# Altium schematic sheet Sheet5.SchDoc
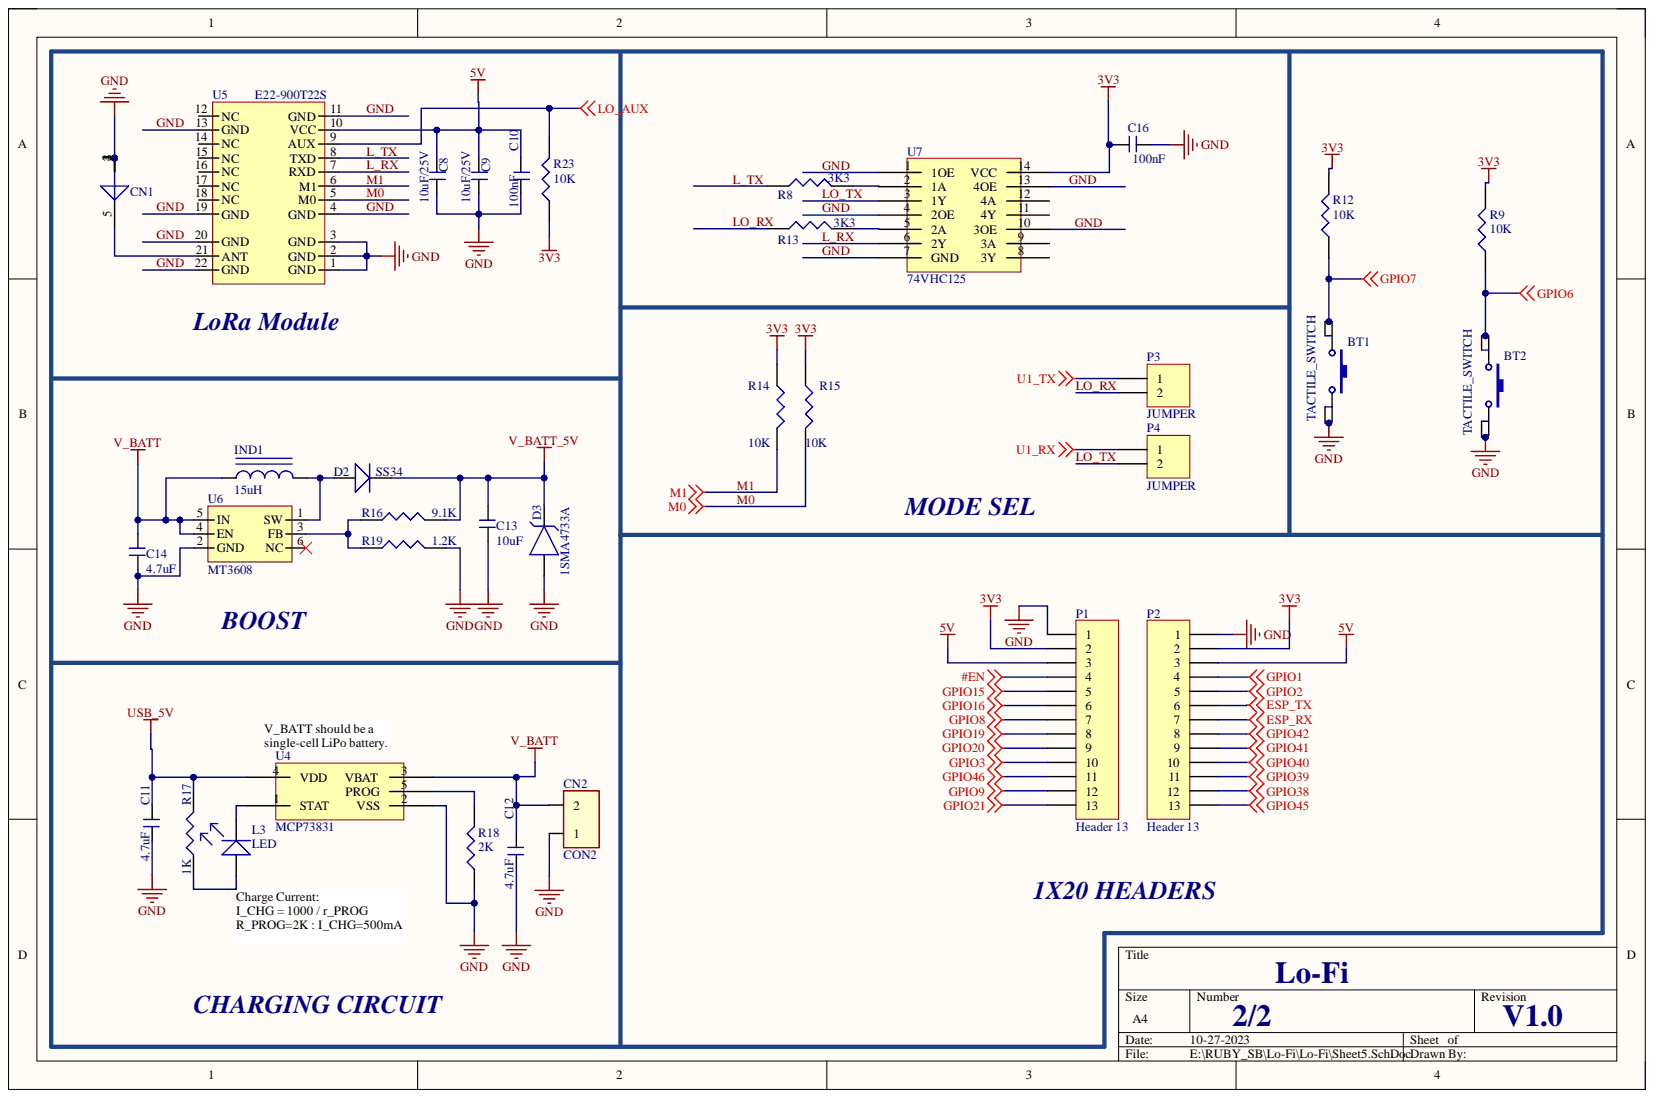
[diagram p0: Sheet5.SchDoc]

## Parts
BT1  TACTILE_SWITCH  [TACTILE]
BT2  TACTILE_SWITCH  [TACTILE]
C8  10uF/25V  [C_0603]
C9  10uF/25V  [C_0603]
C10  100nF  [C_0603]
C11  4.7uF  [C_0603]
C12  4.7uF  [C_0603]
C13  10uF  [C_0603]
C14  4.7uF  [C_0603]
C16  100nF  [C_0603]
CN1  SMA  CONNECTOR  [SMA_L]  (value not drawn)
CN2  CON2  [JST2P_P2.0mm]
D2  SS34  [SS34]
D3  1SMA4733A  [DO-214_AC]
IND1  15uH  [IND 15UH]
L3  LED  [LED_0603]
P1  Header 13  [BERG_13P 2.54MM]
P2  Header 13  [BERG_13P 2.54MM]
P3  JUMPER  [2P_2.54MM]
P4  JUMPER  [2P_2.54MM]
R8  3K3  [R_0603]
R9  10K  [R_0603]
R12  10K  [R_0603]
R13  3K3  [R_0603]
R14  10K  [R_0603]
R15  10K  [R_0603]
R16  9.1K  [R_0603]
R17  1K  [R_0603]
R18  2K  [R_0603]
R19  1.2K  [R_0603]
R23  10K  [R_0603]
U4  MCP73831  [SOT23-5]
U5  E22-900T22S  [LoRa]
U6  MT3608  [SOT23-6]
U7  74VHC125  [SOIC-14]

## Nets
3V3: C16.2 P1.2 P2.2 R9.2 R12.2 R14.1 R15.1 R23.1 U7.14(VCC)
5V: C8.2 C9.2 C10.2 P1.3 P2.3 U5.10(VCC)
#EN: P1.4
ESP_RX: P2.7
ESP_TX: P2.6
GPIO1: P2.4
GPIO2: P2.5
GPIO3: P1.10
GPIO6: BT2.1 BT2.2 R9.1
GPIO7: BT1.1 BT1.2 R12.1
GPIO8: P1.7
GPIO9: P1.12
GPIO15: P1.5
GPIO16: P1.6
GPIO19: P1.8
GPIO20: P1.9
GPIO21: P1.13
GPIO38: P2.12
GPIO39: P2.11
GPIO40: P2.10
GPIO41: P2.9
GPIO42: P2.8
GPIO45: P2.13
GPIO46: P1.11
LO_AUX: R23.2 U5.9(AUX)
U1_RX: P4.1
U1_TX: P3.1
USB_5V: C11.1 R17.2 U4.4(VDD)
V_BATT: C12.1 C14.1 CN2.2 IND1.1 U4.3(VBAT) U6.4(EN) U6.5(IN)
V_BATT_5V: C13.1 D2.K D3.K R16.2
GND: BT1.3 BT1.4 BT2.3 BT2.4 C8.1 C9.1 C10.1 C11.2 C12.2 C13.2 C14.2 C16.1 CN1.1 CN1.2 CN1.3 CN1.4 CN2.1 D3.A P1.1 P2.1 R18.1 R19.2 U4.2(VSS) U5.1(GND) U5.2(GND) U5.3(GND) U5.4(GND) U5.11(GND) U5.13(GND) U5.19(GND) U5.20(GND) U5.22(GND) U6.2(GND) U7.1(1OE) U7.4(2OE) U7.7(GND) U7.10(3OE) U7.13(4OE)
L_RX: U5.7(RXD) U7.6(2Y)
L_TX: R8.1 U5.8(TXD)
LO_RX: P3.2 R13.1
LO_TX: P4.2 U7.3(1Y)
M0: R15.2 U5.5(M0)
M1: R14.2 U5.6(M1)
NetCN1_5: CN1.5 U5.21(ANT)
NetD2_A: D2.A IND1.2 U6.1(SW)
NetL3_A: L3.A R17.1
NetL3_K: L3.K U4.1(STAT)
NetR8_2: R8.2 U7.2(1A)
NetR13_2: R13.2 U7.5(2A)
NetR16_1: R16.1 R19.1 U6.3(FB)
NetR18_2: R18.2 U4.5(PROG)

Not connected: U5 12(NC) 14(NC) 15(NC) 16(NC) 17(NC) 18(NC); U6 6(NC); U7 8(3Y) 9(3A) 11(4Y) 12(4A)

## Library data of the parts
C8-C14 C16 = CAP_NONPOLAR: Component Kind: Standard; Library Name: dxp_pasl_lib.IntLib
CN1 = ANTENNA SMA CONNECTOR: Component Kind: Standard; Library Name: dxp_pasl_lib.IntLib
D2 = DIODE: Component Kind: Standard; Library Name: dxp_pasl_lib.IntLib
D3 = ZENER DIODE: Component Kind: Standard; Library Name: dxp_pasl_lib.IntLib
IND1 = INDUCTOR: Component Kind: Standard; Library Name: dxp_pasl_lib.IntLib
P1 P2 = Header 13: Header, 13-Pin; Component Kind: Standard; LatestRevisionDate: 17-Jul-2002; LatestRevisionNote: Re-released for DXP Platform.; Library Name: Miscellaneous Connectors.IntLib; Signal Integrity: Connector; Publisher: Altium Limited
P3 P4 = Header 2H: Header, 2-Pin, Right Angle; Component Kind: Standard; LatestRevisionDate: 17-Jul-2002; LatestRevisionNote: Re-released for DXP Platform.; Library Name: Miscellaneous Connectors.IntLib; Signal Integrity: Connector; Publisher: Altium Limited
R8 R9 R12-R19 R23 = RES: Component Kind: Standard; Library Name: dxp_pasl_lib.IntLib
U6 = MT3608: 28V~28V 2V~24V 1.2MHz SOT-23-6 DC-DC Converters ROHS #C84817; Component Kind: Standard; Library Name: dxp_pasl_lib.IntLib
BT1 BT2 = TACTILE_SWITCH: Component Kind: Standard; Library Name: dxp_pasl_lib.IntLib
CN2 = CON2: Component Kind: Standard; Library Name: dxp_pasl_lib.IntLib
L3 = LED: Component Kind: Standard; Library Name: dxp_pasl_lib.IntLib
U4 = MCP73831: Component Kind: Standard; Library Name: dxp_pasl_lib.IntLib
U5 = E22-900T22S: Component Kind: Standard; Library Name: dxp_pasl_lib.IntLib
U7 = 74VHC125: Component Kind: Standard; Library Name: dxp_pasl_lib.IntLib
